# Altium schematic sheet W25Q128JVSIQ_Flash_Mem.SchDoc(W25Q128JVSIQ_Flash_Mem)
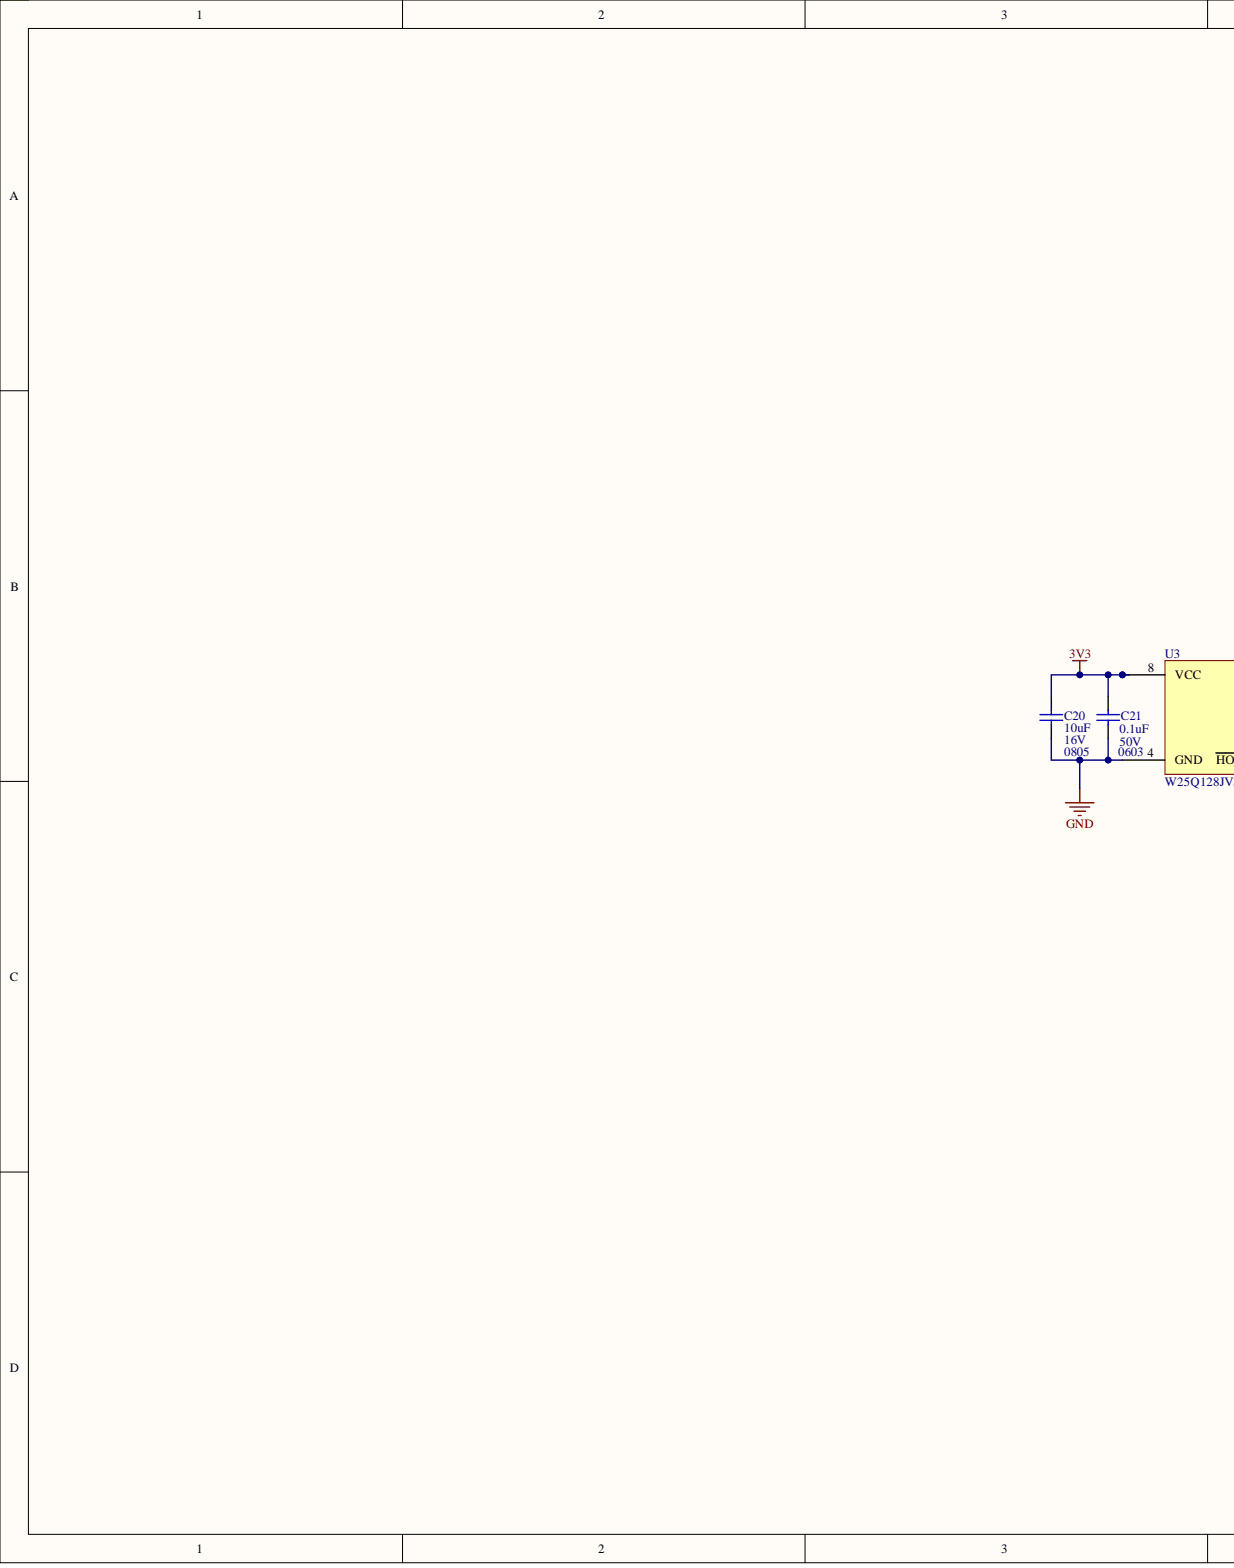
[diagram p0: W25Q128JVSIQ_Flash_Mem.SchDoc, left]
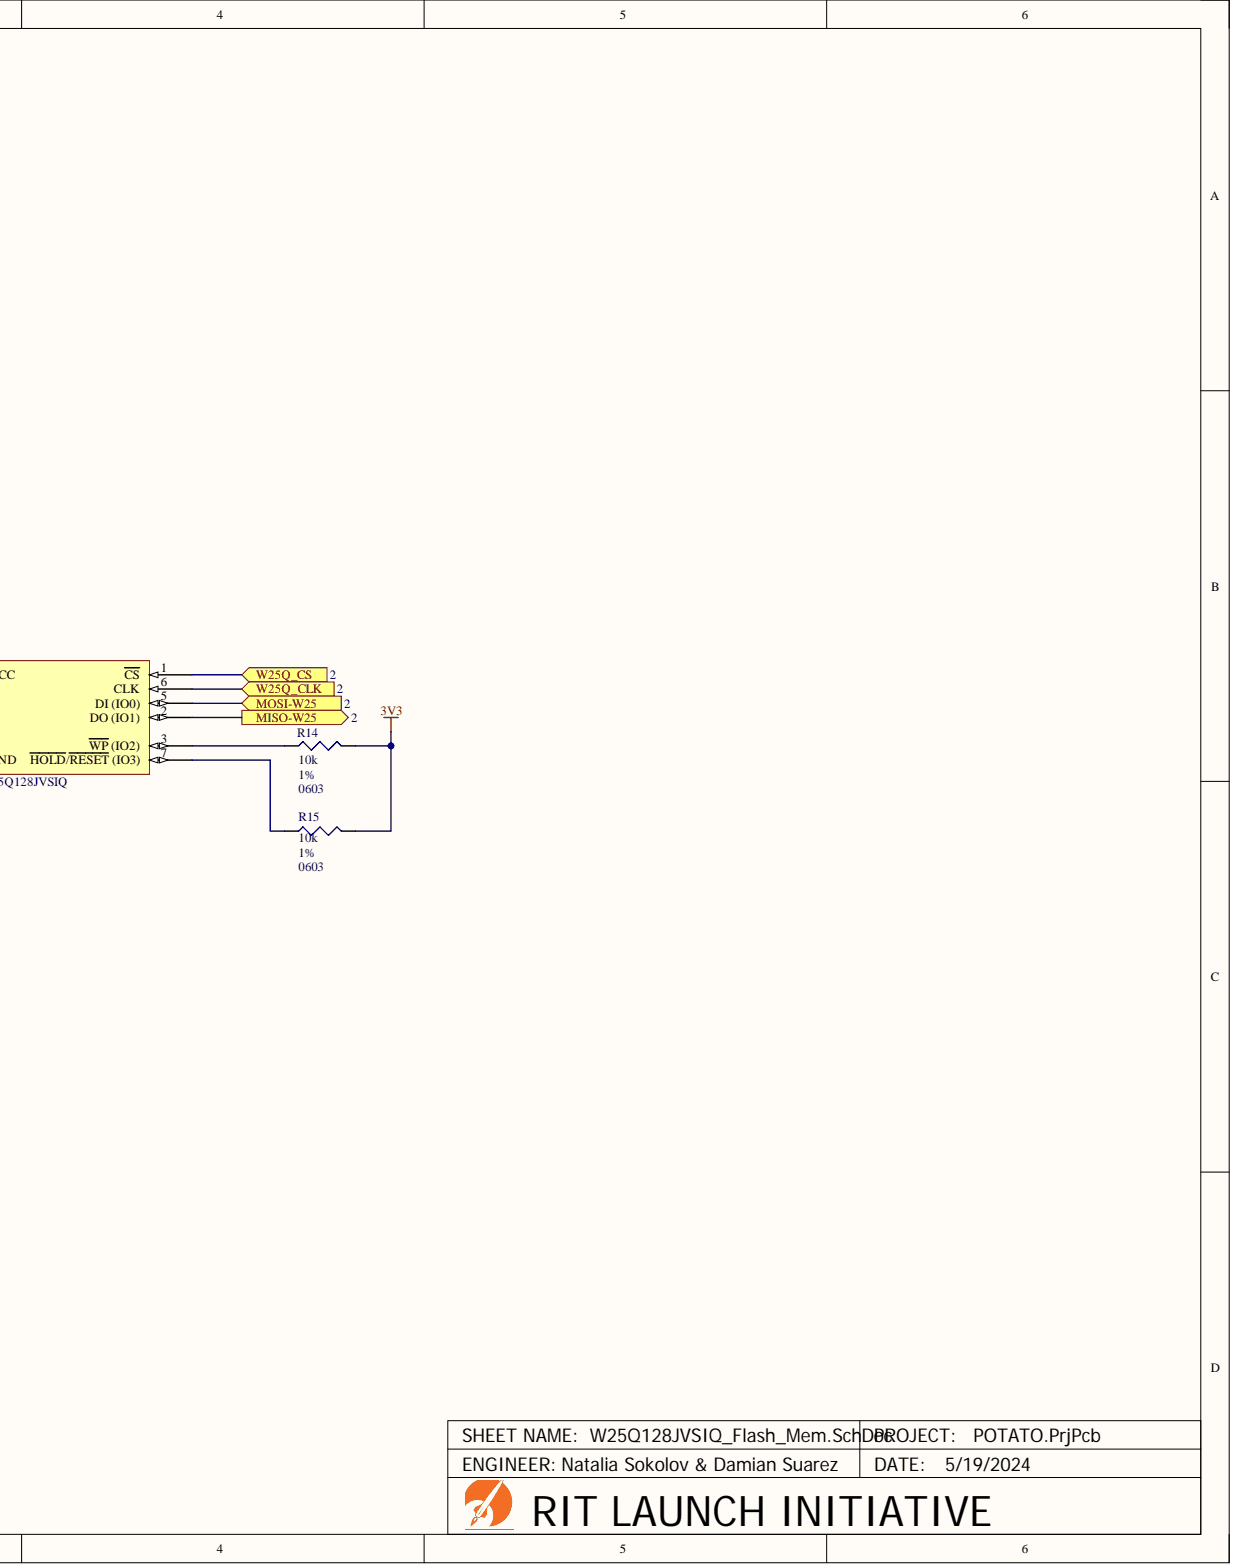
[diagram p1: W25Q128JVSIQ_Flash_Mem.SchDoc, right]

## Parts
C20  Capacitance: 10uF  Case Code (Imperial): 0805  Voltage: 16V  (hidden comment: CL21A106KOQNNNE)
C21  Capacitance: 0.1uF  Voltage: 50V  (hidden comment: CC0603KRX7R9BB104)
R14  Resistance: 10k  Tolerance: 1%  (hidden comment: 0603WAF1002T5E)
R15  Case/Package: 0603  Resistance: 10k  Tolerance: 1%  (hidden comment: 0603WAF1002T5E)
U3  W25Q128JVSIQ

## Ports
MISO-W25, MOSI-W25, W25Q_CLK, W25Q_CS

## Nets
3V3: C20.2 C21.2 R14.2 R15.2 U3.8(VCC)
GND: C20.1 C21.1 U3.4(GND)
MISO-W25: U3.2(DO_(IO1)) ; port MISO-W25
MOSI-W25: U3.5(DI_(IO0)) ; port MOSI-W25
W25Q_CLK: U3.6(CLK) ; port W25Q_CLK
W25Q_CS: U3.1(CS) ; port W25Q_CS
NetR14_1: R14.1 U3.3(WP_(IO2))
NetR15_1: R15.1 U3.7(HOLD/RESET_(IO3))

## Library data of the parts
C20 = CMP-001-0207-3: CAP CER 10UF 16V X5R 0805; Tolerance: 10%; Case Code (Metric): 2012; Case/Package: 0805; Component Kind: Standard; Construction: Flat; Depth: 1.25mm; Dielectric: X5R; Height: 1.25mm; JLCPCB Part #: C1713; Lead Free: Lead Free; Length: 2mm; Max Operating Temperature: 85°C; Min Operating Temperature: -55°C; Mount: Surface Mount; Package Quantity: 1; Packaging: Tape and Reel; Radiation Hardening: No; RoHS Compliant: Yes; Series: CL; Thickness: 0.055inch; Voltage Rating: 16V; Voltage Rating (DC): 16V; Width: 0.049inch; Library Name: RIT Launch Initiative
C21 = CMP-001-0242-3: CAP CER 0.1UF 50V X7R 0603; Dielectric Material: Ceramic; Tolerance: 10%; Case Code (Imperial): 0603; Case Code (Metric): 1608; Case/Package: 0603; Component Kind: Standard; Depth: 0.8mm; Dielectric: X7R; Halogen Free: Halogen Free; Height: 0.8mm; JLCPCB Part #: C14663; Lead Free: Lead Free; Length: 0.063inch; Max Operating Temperature: 125°C; Min Operating Temperature: -55°C; Mount: Surface Mount; Number of Pins: 2; Package Quantity: 1; Packaging: Tape and Reel; Radiation Hardening: No; REACH SVHC: No SVHC; RoHS Compliant: Yes; Series: CC; Termination: SMD/SMT; Thickness: 0.035inch; Voltage Rating: 50V; Width: 0.031inch; Library Name: RIT Launch Initiative
R14 R15 = CMP-001-0268-1: RES 10K OHM 1% 1/10W 0603; Component Kind: Standard; JLCPCB Part #: C25804; RoHS Compliant: Yes; Library Name: RIT Launch Initiative
  R14: Case/Package: 0603
U3 = CMP-001-0257-8: NOR Flash Serial (SPI, Dual SPI, Quad SPI), 3.3V, 128M-bit, 8-Pin SOIC; Interface: SPI,Serial; Memory Type: Non-Volatile NOR FLASH; Operating Voltage: 2.7 to 3.6V; Case/Package: 8-Pin SOIC; Component Kind: Standard; JLCPCB Part #: C113767; Memory Size: 128M-bit; Operating Temperature Range: -40°C to 85°C; RoHS Compliant: Yes; Series: SpiFlash®; Library Name: RIT Launch Initiative
